# Altium schematic sheet 07_CAN.SchDoc(07_CAN)
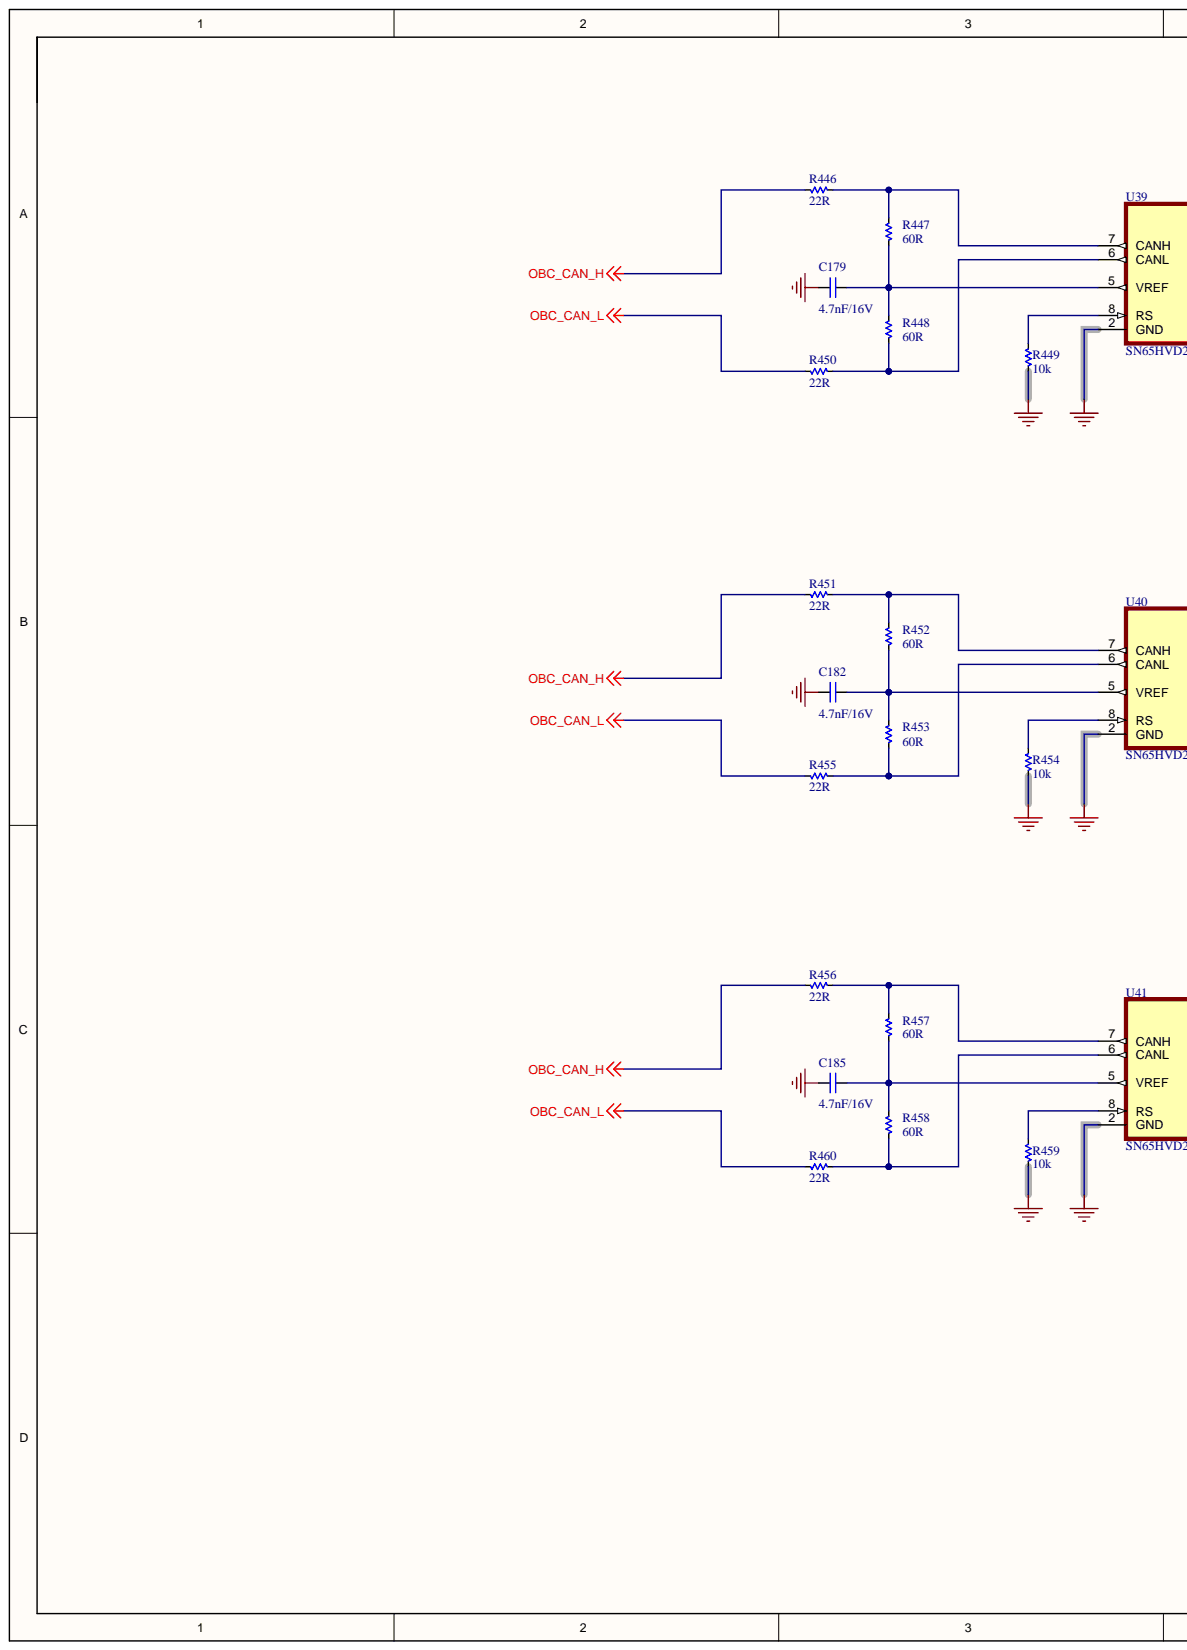
[diagram p0: 07_CAN.SchDoc, left]
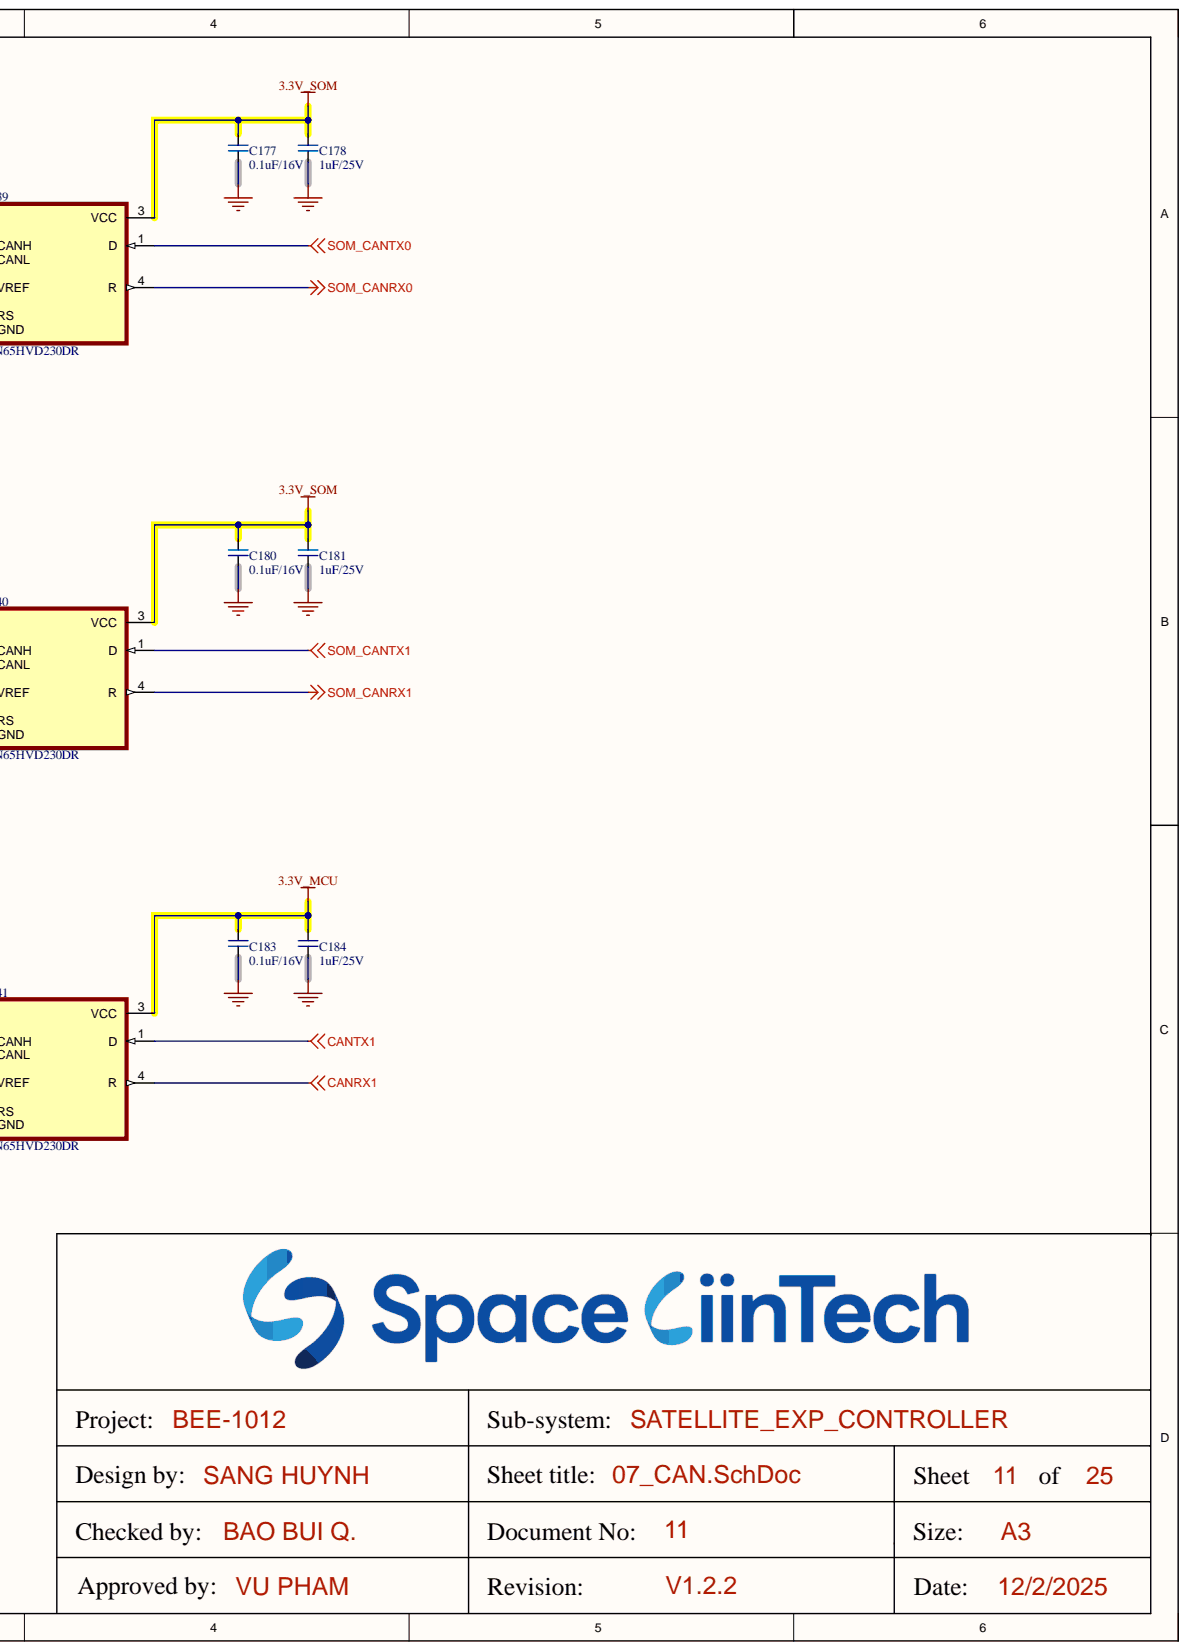
[diagram p1: 07_CAN.SchDoc, right]

## Parts
C177  0.1uF/16V  [CAP_C_1608X80]
C178  1uF/25V  [CAP_C_1608X80]
C179  4.7nF/16V  [CAP_C_1608X80]
C180  0.1uF/16V  [CAP_C_1608X80]
C181  1uF/25V  [CAP_C_1608X80]
C182  4.7nF/16V  [CAP_C_1608X80]
C183  0.1uF/16V  [CAP_C_1608X80]
C184  1uF/25V  [CAP_C_1608X80]
C185  4.7nF/16V  [CAP_C_1608X80]
R446  22R  [RES_C_1608X60]
R447  60R  [RES_C_1608X60]
R448  60R  [RES_C_1608X60]
R449  10k  [RES_C_1608X60]
R450  22R  [RES_C_1608X60]
R451  22R  [RES_C_1608X60]
R452  60R  [RES_C_1608X60]
R453  60R  [RES_C_1608X60]
R454  10k  [RES_C_1608X60]
R455  22R  [RES_C_1608X60]
R456  22R  [RES_C_1608X60]
R457  60R  [RES_C_1608X60]
R458  60R  [RES_C_1608X60]
R459  10k  [RES_C_1608X60]
R460  22R  [RES_C_1608X60]
U39  SN65HVD230DR  [SOIC127P599X175-8N]
U40  SN65HVD230DR  [SOIC127P599X175-8N]
U41  SN65HVD230DR  [SOIC127P599X175-8N]

## Nets
3.3V_MCU: C183.1 C184.1 U41.3(VCC)
3.3V_SOM: C177.1 C178.1 C180.1 C181.1 U39.3(VCC) U40.3(VCC)
CANRX1: U41.4(R)
CANTX1: U41.1(D)
GND: C177.2 C178.2 C179.2 C180.2 C181.2 C182.2 C183.2 C184.2 C185.2 R449.1 R454.1 R459.1 U39.2(GND) U40.2(GND) U41.2(GND)
OBC_CAN_H: R446.1 R451.1 R456.1
OBC_CAN_L: R450.1 R455.1 R460.1
SOM_CANRX0: U39.4(R)
SOM_CANRX1: U40.4(R)
SOM_CANTX0: U39.1(D)
SOM_CANTX1: U40.1(D)
NetC179_1: C179.1 R447.1 R448.2 U39.5(VREF)
NetC182_1: C182.1 R452.1 R453.2 U40.5(VREF)
NetC185_1: C185.1 R457.1 R458.2 U41.5(VREF)
NetR446_2: R446.2 R447.2 U39.7(CANH)
NetR448_1: R448.1 R450.2 U39.6(CANL)
NetR449_2: R449.2 U39.8(RS)
NetR451_2: R451.2 R452.2 U40.7(CANH)
NetR453_1: R453.1 R455.2 U40.6(CANL)
NetR454_2: R454.2 U40.8(RS)
NetR456_2: R456.2 R457.2 U41.7(CANH)
NetR458_1: R458.1 R460.2 U41.6(CANL)
NetR459_2: R459.2 U41.8(RS)

## Library data of the parts
C177-C185 = CAP_SMD: CAP_Chip; Value: 33pF; Component Kind: Standard; Library Name: LABLIB.SchLib
R446-R460 = _RES_B_2: RES; Component Kind: Standard; Library Name: LABLIB.SchLib
U39-U41 = SN65HVD230DR: 3.3 V CAN Transceiver with Standby Mode; MF: Texas Instruments; Check_prices: https://www.snapeda.com/parts/SN65HVD230DR/Texas+Instruments/view-part/?ref=eda; Component Kind: Standard; MP: SN65HVD230DR; Package: SOIC-8 Texas Instruments; SnapEDA_Link: https://www.snapeda.com/parts/SN65HVD230DR/Texas+Instruments/view-part/?ref=snap; Library Name: COMM_SCHLIB.SchLib
